# Altium schematic sheet Input Filtering.SchDoc("Input Filtering")
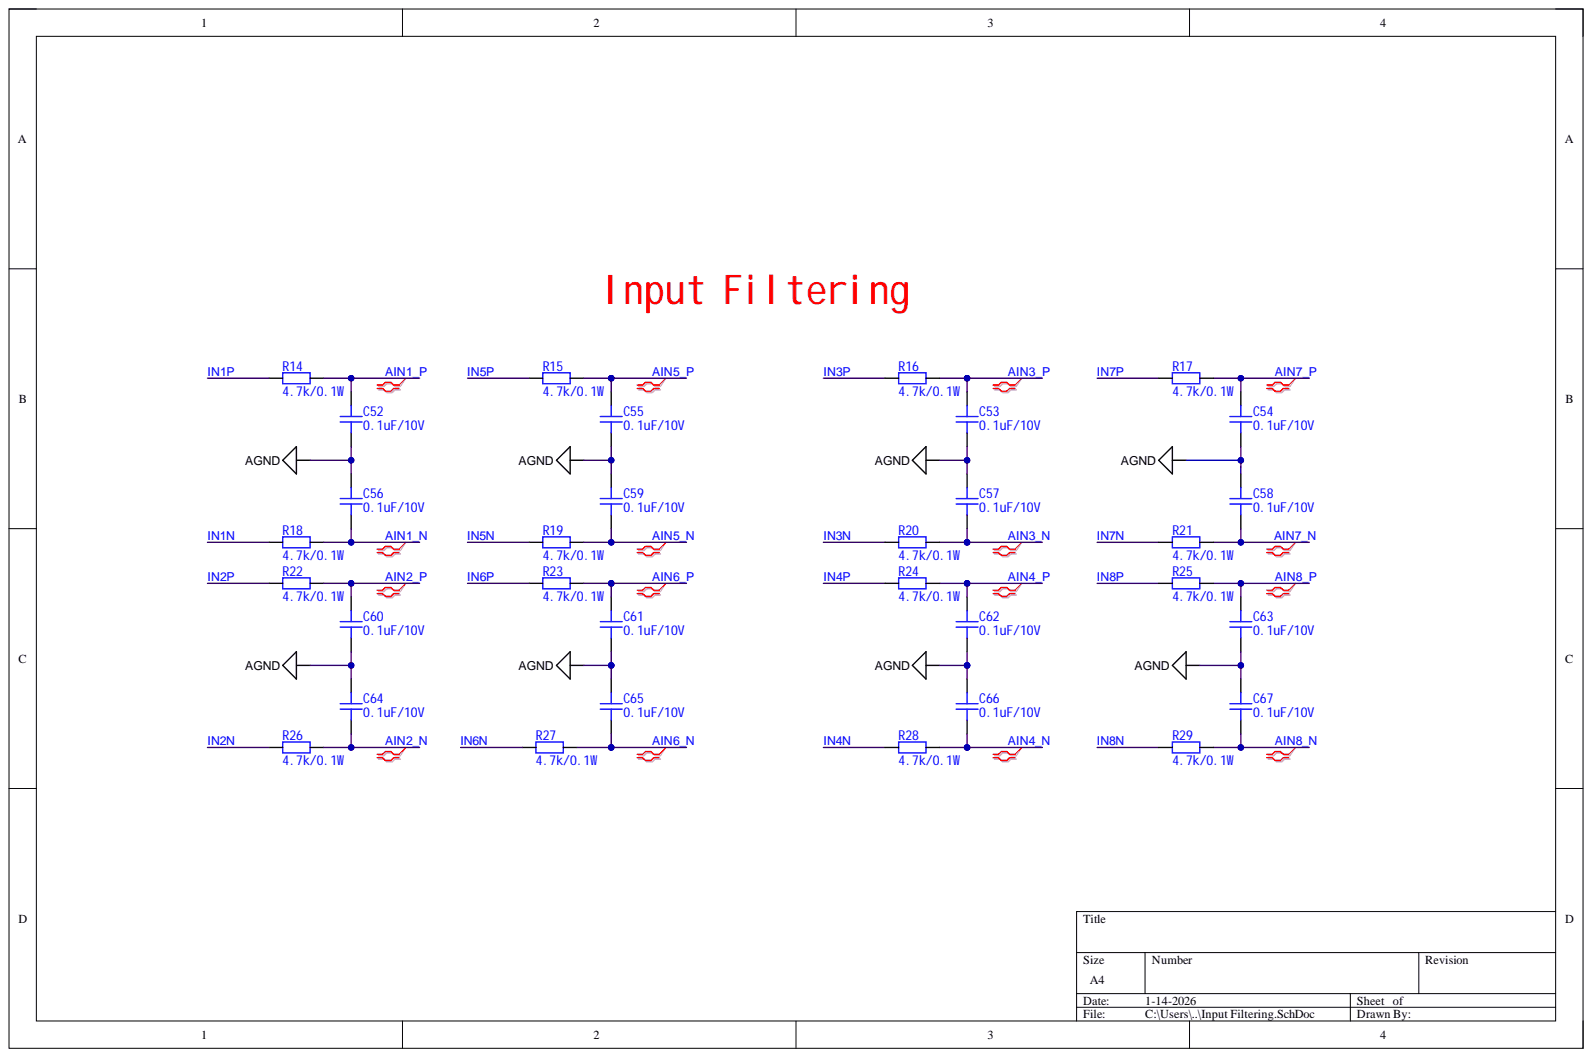
[diagram p0: Input Filtering.SchDoc]

## Parts
C52  0.1uF  value 0.1uF/10V  [C0603]
C53  0.1uF  value 0.1uF/10V  [C0603]
C54  0.1uF  value 0.1uF/10V  [C0603]
C55  0.1uF  value 0.1uF/10V  [C0603]
C56  0.1uF  value 0.1uF/10V  [C0603]
C57  0.1uF  value 0.1uF/10V  [C0603]
C58  0.1uF  value 0.1uF/10V  [C0603]
C59  0.1uF  value 0.1uF/10V  [C0603]
C60  0.1uF  value 0.1uF/10V  [C0603]
C61  0.1uF  value 0.1uF/10V  [C0603]
C62  0.1uF  value 0.1uF/10V  [C0603]
C63  0.1uF  value 0.1uF/10V  [C0603]
C64  0.1uF  value 0.1uF/10V  [C0603]
C65  0.1uF  value 0.1uF/10V  [C0603]
C66  0.1uF  value 0.1uF/10V  [C0603]
C67  0.1uF  value 0.1uF/10V  [C0603]
R14  4.7k  value 4.7k/0.1W  [R0603]
R15  4.7k  value 4.7k/0.1W  [R0603]
R16  4.7k  value 4.7k/0.1W  [R0603]
R17  4.7k  value 4.7k/0.1W  [R0603]
R18  4.7k  value 4.7k/0.1W  [R0603]
R19  4.7k  value 4.7k/0.1W  [R0603]
R20  4.7k  value 4.7k/0.1W  [R0603]
R21  4.7k  value 4.7k/0.1W  [R0603]
R22  4.7k  value 4.7k/0.1W  [R0603]
R23  4.7k  value 4.7k/0.1W  [R0603]
R24  4.7k  value 4.7k/0.1W  [R0603]
R25  4.7k  value 4.7k/0.1W  [R0603]
R26  4.7k  value 4.7k/0.1W  [R0603]
R27  4.7k  value 4.7k/0.1W  [R0603]
R28  4.7k  value 4.7k/0.1W  [R0603]
R29  4.7k  value 4.7k/0.1W  [R0603]

## Nets
AGND: C52.2 C53.2 C54.2 C55.2 C56.1 C57.1 C58.1 C59.1 C60.2 C61.2 C62.2 C63.2 C64.1 C65.1 C66.1 C67.1
AIN1_N: C56.2 R18.2
AIN1_P: C52.1 R14.2
AIN2_N: C64.2 R26.2
AIN2_P: C60.1 R22.2
AIN3_N: C57.2 R20.2
AIN3_P: C53.1 R16.2
AIN4_N: C66.2 R28.2
AIN4_P: C62.1 R24.2
AIN5_N: C59.2 R19.2
AIN5_P: C55.1 R15.2
AIN6_N: C65.2 R27.2
AIN6_P: C61.1 R23.2
AIN7_N: C58.2 R21.2
AIN7_P: C54.1 R17.2
AIN8_N: C67.2 R29.2
AIN8_P: C63.1 R25.2
IN1N: R18.1
IN1P: R14.1
IN2N: R26.1
IN2P: R22.1
IN3N: R20.1
IN3P: R16.1
IN4N: R28.1
IN4P: R24.1
IN5N: R19.1
IN5P: R15.1
IN6N: R27.1
IN6P: R23.1
IN7N: R21.1
IN7P: R17.1
IN8N: R29.1
IN8P: R25.1

## Library data of the parts
C52-C67 = CC0603KRX7R6BB104: CAP CER 0.1UF 10V X7R 0603; Add into BOM: yes; Device: CAP_0603; Component Kind: Standard; ComponentLink1Description: Product link; ComponentLink1URL: https://www.digikey.in/en/products/detail/yageo/CC0603KRX7R6BB104/5883741; Convert to PCB: yes; Library Name: US00001.SCHLIB; Supplier Footprint: 0603; Symbol: CAP
R14-R29 = RC0603FR-134K7L: RES 4.7K OHM 1% 1/10W 0603; Add into BOM: yes; Device: Res_0603; Component Kind: Standard; ComponentLink1Description: Product link; ComponentLink1URL: https://www.digikey.in/en/products/detail/yageo/RC0603FR-134K7L/13694456?gclsrc=aw.ds&gad_source=1&gad_campaignid=23291916465&gbraid=0AAAAADrbLlj70qxStastrNTiTtbZYZxnf&gclid=CjwKCAiAjojLBhAlEiwAcjhrDih7UiqGp27o5fHELb6WSNmvTd6HGZDoEg_am2IlnZvnTOwhKgG11hoCu-QQAvD_BwE; Convert to PCB: yes; Library Name: US00001.SCHLIB; Supplier Footprint: 0603; Symbol: Res
